# Altium schematic sheet BoostConverter.SchDoc
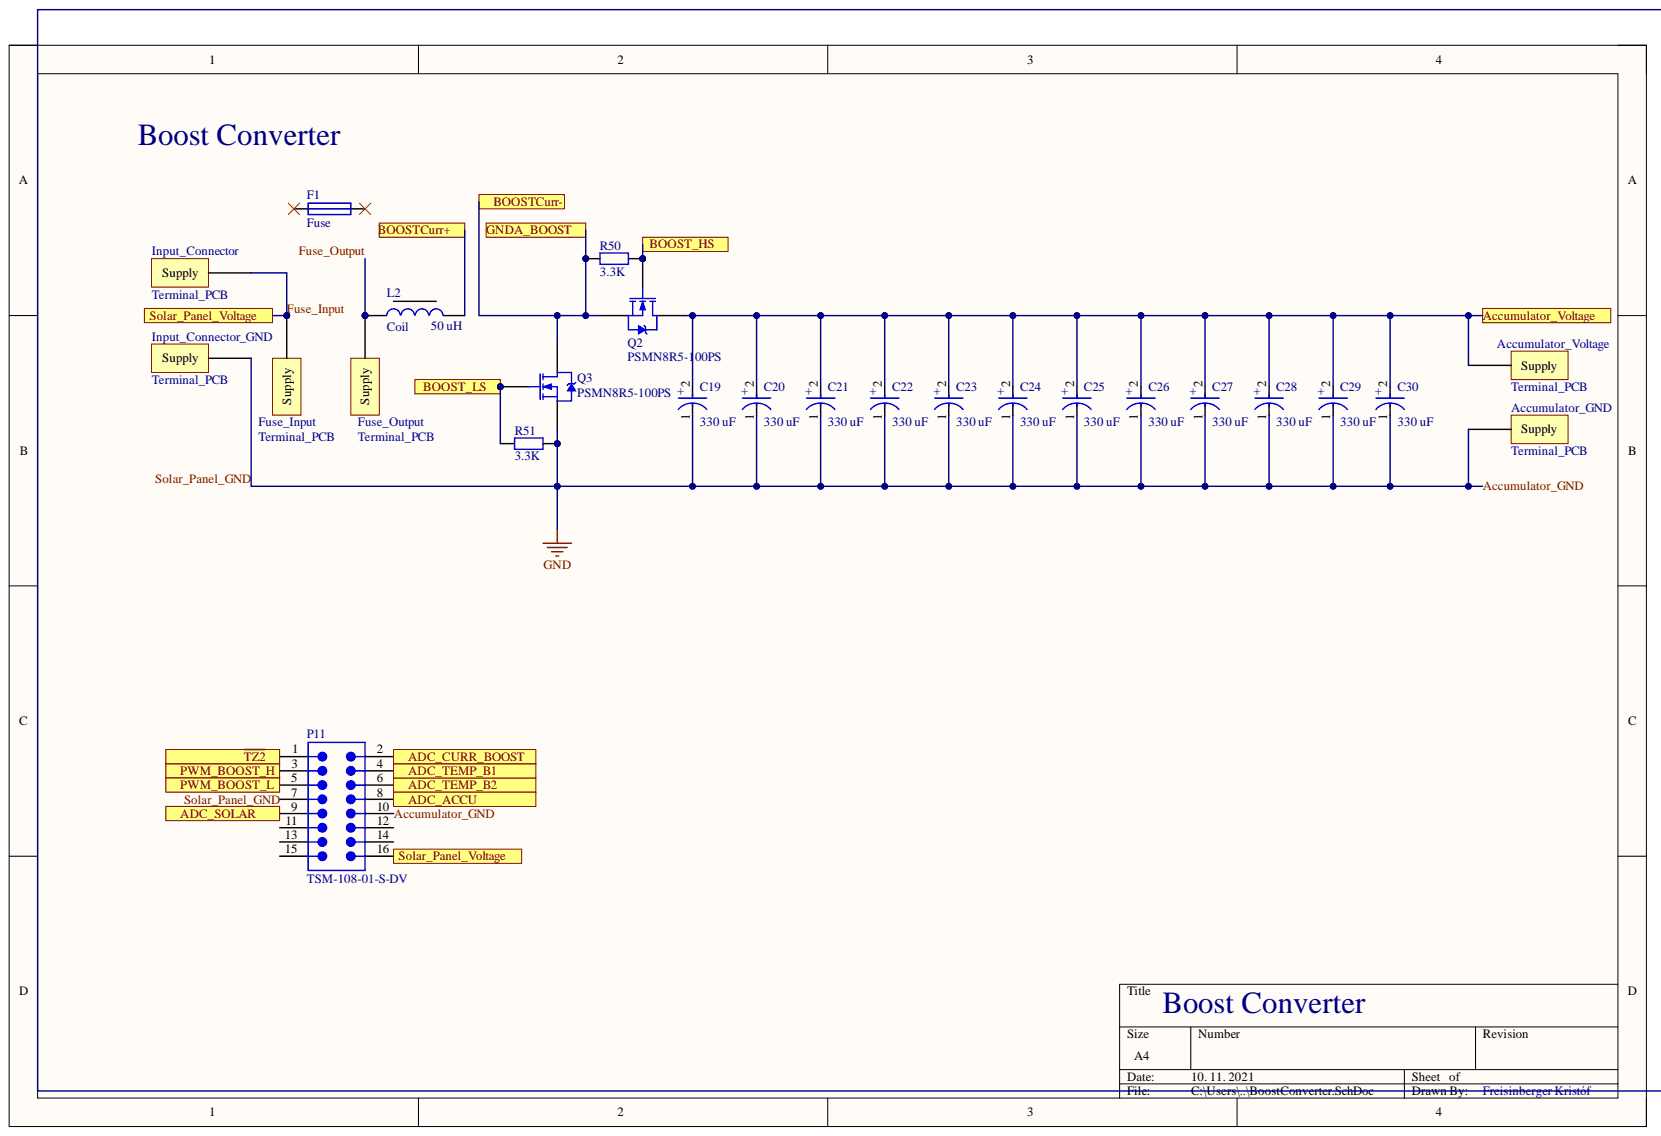
[diagram p0: BoostConverter.SchDoc]

## Parts
Accumulator_GND  Terminal_PCB  [Terminal_PCB_7798]
Accumulator_Voltage  Terminal_PCB  [Terminal_PCB_7798]
C19  330 uF  [FP-LXW18X25-MFG]
C20  330 uF  [FP-LXW18X25-MFG]
C21  330 uF  [FP-LXW18X25-MFG]
C22  330 uF  [FP-LXW18X25-MFG]
C23  330 uF  [FP-LXW18X25-MFG]
C24  330 uF  [FP-LXW18X25-MFG]
C25  330 uF  [FP-LXW18X25-MFG]
C26  330 uF  [FP-LXW18X25-MFG]
C27  330 uF  [FP-LXW18X25-MFG]
C28  330 uF  [FP-LXW18X25-MFG]
C29  330 uF  [FP-LXW18X25-MFG]
C30  330 uF  [FP-LXW18X25-MFG]
F1  Fuse
Fuse_Input  Terminal_PCB  [Terminal_PCB_7798]
Fuse_Output  Terminal_PCB  [Terminal_PCB_7798]
Input_Connector  Terminal_PCB  [Terminal_PCB_7798]
Input_Connector_GND  Terminal_PCB  [Terminal_PCB_7798]
L2  Coil  value 50 uH  [Tekercs_E65_FERRITE]
P11  TSM-108-01-S-DV  [SMTC-TSM-108-01-X-DV]
Q2  PSMN8R5-100PS  [FP-TO-220AB-MFG]
Q3  PSMN8R5-100PS  [FP-RA-T2X-64E-MFG]
R50  3.3K  [FP_0603_RES]
R51  3.3K  [FP_0603_RES]

## Ports
Accumulator_Voltage, ADC_ACCU, ADC_CURR_BOOST, ADC_SOLAR, ADC_TEMP_B1, ADC_TEMP_B2, BOOST_HS, BOOST_LS, BOOSTCurr+, BOOSTCurr-, GNDA_BOOST, PWM_BOOST_H, PWM_BOOST_L, Solar_Panel_Voltage, ~{TZ2}

## Nets
Accumulator_GND: Accumulator_GND.1 C19.1 C20.1 C21.1 C22.1 C23.1 C24.1 C25.1 C26.1 C27.1 C28.1 C29.1 C30.1 Input_Connector_GND.1 P11.7 P11.10 Q3.3 R51.2
Accumulator_Voltage: Accumulator_Voltage.1 C19.2 C20.2 C21.2 C22.2 C23.2 C24.2 C25.2 C26.2 C27.2 C28.2 C29.2 C30.2 Q2.2 ; port Accumulator_Voltage
ADC_ACCU: P11.8 ; port ADC_ACCU
ADC_CURR_BOOST: P11.2 ; port ADC_CURR_BOOST
ADC_SOLAR: P11.9 ; port ADC_SOLAR
ADC_TEMP_B1: P11.4 ; port ADC_TEMP_B1
ADC_TEMP_B2: P11.6 ; port ADC_TEMP_B2
BOOST_HS: Q2.1 R50.2 ; port BOOST_HS
BOOST_LS: Q3.1 R51.1 ; port BOOST_LS
Fuse_Input: Fuse_Input.1 Input_Connector.1 P11.16 ; port Solar_Panel_Voltage
Fuse_Output: Fuse_Output.1 L2.1
GNDA_BOOST: Q2.3 Q3.2 R50.1 ; port GNDA_BOOST
PWM_BOOST_H: P11.3 ; port PWM_BOOST_H
PWM_BOOST_L: P11.5 ; port PWM_BOOST_L
~{TZ2}: P11.1 ; port ~{TZ2}
NetL2_2: L2.2

Not connected: F1 1 2; P11 11 12 13 14 15

## Library data of the parts
C19-C30 = CMP-35376-000008-1: CAP ALUM 47UF 20% 500V THRUHOLE  500LXW47MEFR18X25; Component Kind: Standard; Library Name: Altium Content Vault
F1 = Fuse_Fork_Lift_157.5700.5501: LITTELFUSE   157.5700.5501   Automotive Fuse, Fork Lift Truck, 157.5700 Series, 50 A, Fast Acting, 48 V; Body Material: ZINC ALLOY; Current Rating: 50A; Type: Electrical; Voltage Rating: 80V; Voltage Rating (DC): 48V; Category: Fuses; Component Kind: Standard; ComponentLink1Description: https://datasheet.ciiva.com/1729/68907-1729142.pdf?src-supplier=Newark; ComponentLink1URL: https://datasheet.ciiva.com/1729/68907-1729142.pdf?src-supplier=Newark; ComponentLink2Description: https://datasheet.ciiva.com/1547/68907-1547992.pdf?src-supplier=Element14; ComponentLink2URL: https://datasheet.ciiva.com/1547/68907-1547992.pdf?src-supplier=Element14; Fuse Current: 50A; Height: 0.03inch; Length: 82mm; Manufacturer: Littelfuse; Manufacturer Part Number: 157.5700.5501; Max Operating Temperature: 125°C; Min Operating Temperature: -40°C; Mount: Bolt; Packaging: Bulk; Pricing 1: 1=1,98000, 5=1,89000, 10=1,79600, 50=1,44900, 100=1,22850, 250=1,19700, 500=1,00800, 1000=0,89775, 5000=0,83790 (USD); REACH SVHC: Unknown; RoHS: Yes; RoHS Compliant: Yes; Series: -; Stock 1: 20; Supplier 1: Digi-Key; Termination: Lug; Width: 20mm; Library Name: Napelem_Inverter.SchLib
L2 = Coil: 50 uH; Category: Inductor; Component Kind: Standard; Library Name: Napelem_Inverter.SchLib
P11 = CMP-1024-00270-1: 0.025" SQ Post Header, Surface Mount, Vertical, -55 to 125 degC, 2.54 mm Pitch, 16-Pin, Male, RoHS; Manufacturer: Samtec; Pitch: 2.54 mm; Component Kind: Standard; ComponentLink1Description: Manufacturer URL; ComponentLink1URL: http://www.samtec.com; ComponentLink2Description: Datasheet; ComponentLink2URL: http://www.samtec.com/documents/webfiles/pdf/TSM.PDF; ComponentLink3Description: Package Specification; ComponentLink3URL: http://www.samtec.com/documents/webfiles/cpdf/TSM-1XX-XX-XXX-XX-X-XXX-X-MKT.pdf; DatasheetVersion: F-214; Library Name: Altium Content Vault; Mounting Technology: Surface Mount; Orientation: Vertical; PackageDescription: Double Row Gullwing ASM; PackageReference: TSM-108-01-X-DV; PackageVersion: DJ, 4/1991; PartNumber: TSM-108-01-S-DV; RoHS: True; Temperature Range: -55 to 125 degC
Q2 Q3 = NMOS-2: N-channel 100 V 8.5 mΩ standard level MOSFET in TO220; Note: Pin 2 is electrically connected to pin 4.; Component Kind: Standard; ComponentLink1Description: Manufacturer Link; ComponentLink1URL: http://www.irf.com/; DatasheetVersion: PD-9.814; LatestRevisionDate: 17-Jul-2002; LatestRevisionNote: Re-released for DXP Platform.; Library Name: Miscellaneous Devices.IntLib; PackageDescription: TO, Thru-Hole, Vertical, Heatsink Mounted; 3 In-Line Leads; Pitch 2.54 mm; PackageReference: TO-220AB; PackageVersion: Feb-1997; Published: 8-Jun-2000; Simulation: IRF1010; Publisher: Altium Limited
R50 R51 = RES: Resistor; Component Kind: Standard; Value: 3.3K; Library Name: Napelem_Inverter.SchLib
Accumulator_GND Accumulator_Voltage Fuse_Input Fuse_Output Input_Connector Input_Connector_GND = Terminal_PCB: Component Kind: Standard; Library Name: Napelem_Inverter.SchLib
